# Altium schematic sheet Analog_power.SchDoc(Analog_power)
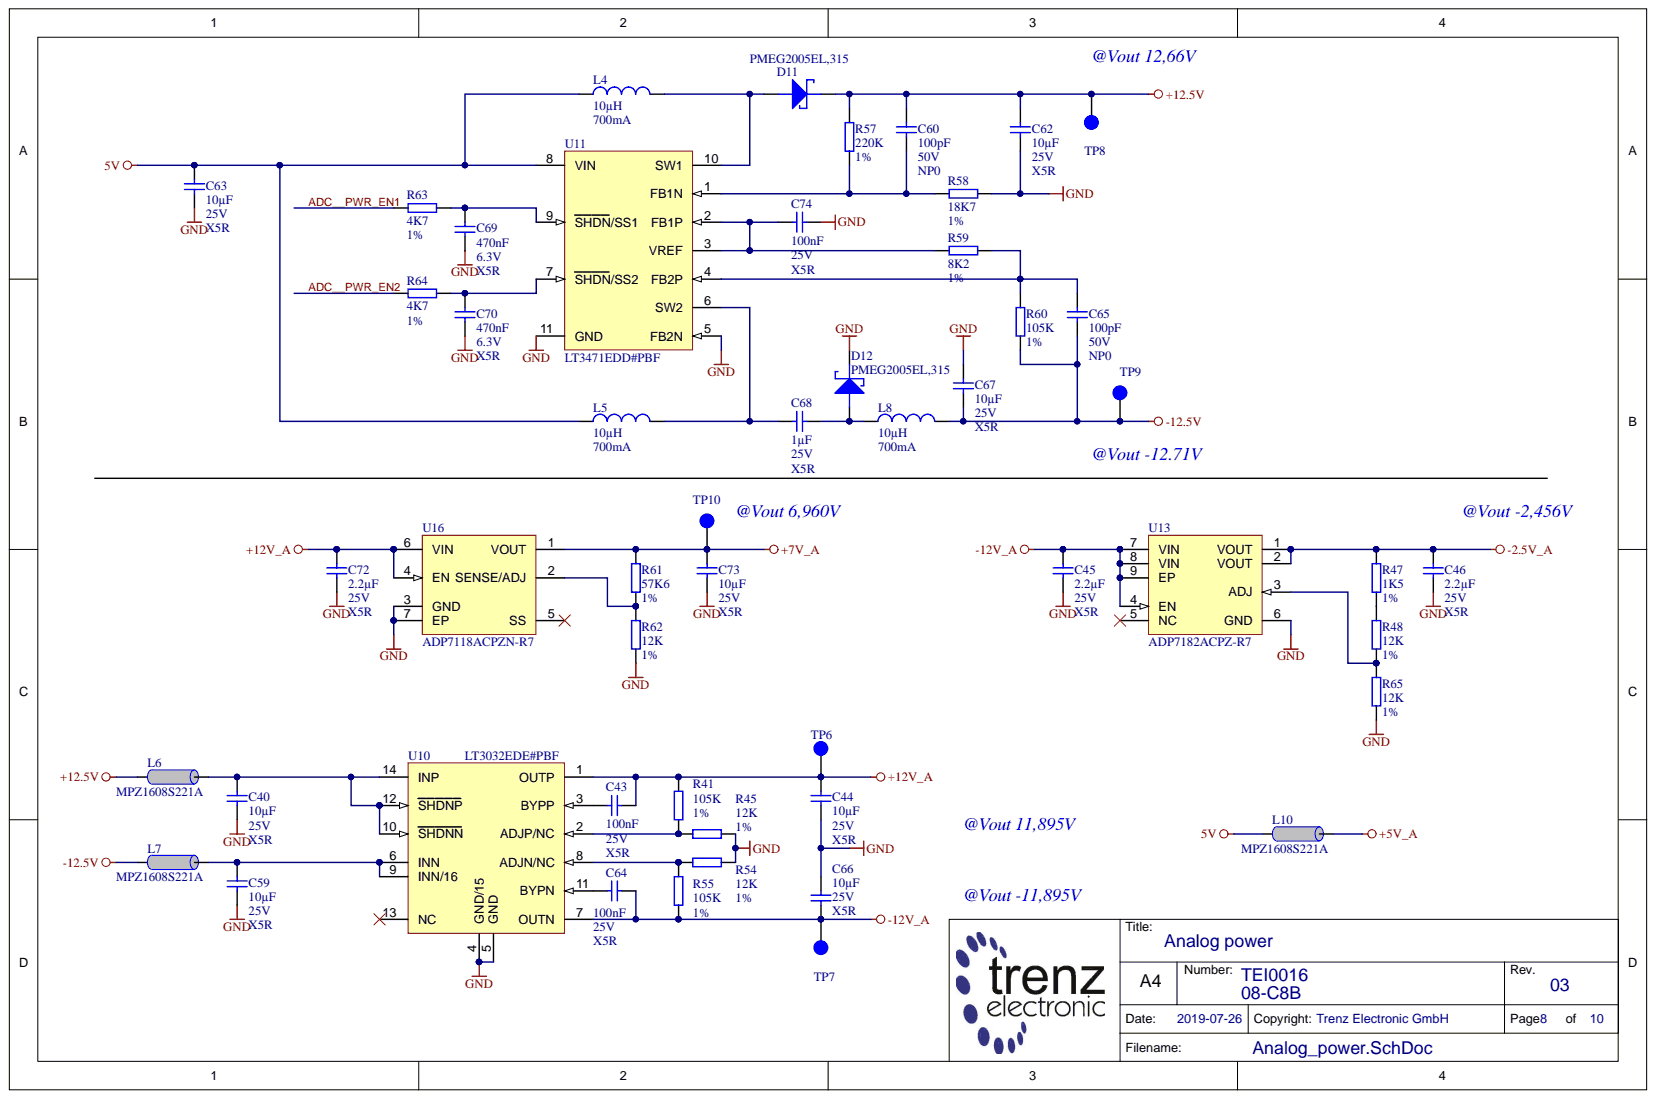
[diagram p0: Analog_power.SchDoc]

## Parts
C40  10µF  Technology: X5R  Voltage: 25V  [CAPC2012X135N]
C43  100nF  [CAPC1005X55N]
C44  10µF  Technology: X5R  Voltage: 25V  [CAPC2012X135N]
C45  2.2µF  Technology: X5R  Voltage: 25V  [CAPC1608X90N]
C46  2.2µF  Technology: X5R  Voltage: 25V  [CAPC1608X90N]
C59  10µF  Technology: X5R  Voltage: 25V  [CAPC2012X135N]
C60  100pF  Voltage: 50V  [CAPC1005X55N]
C62  10µF  Technology: X5R  Voltage: 25V  [CAPC2012X135N]
C63  10µF  Technology: X5R  Voltage: 25V  [CAPC2012X135N]
C64  100nF  Technology: X5R  [CAPC1005X55N]
C65  100pF  Voltage: 50V  [CAPC1005X55N]
C66  10µF  Technology: X5R  Voltage: 25V  [CAPC2012X135N]
C67  10µF  Technology: X5R  Voltage: 25V  [CAPC2012X135N]
C68  1µF  Voltage: 25V  [CAPC1608X90N]
C69  470nF  Technology: X5R  Voltage: 6.3V  [CAPC0603X33N]
C70  470nF  Technology: X5R  Voltage: 6.3V  [CAPC0603X33N]
C72  2.2µF  Technology: X5R  Voltage: 25V  [CAPC1608X90N]
C73  10µF  Technology: X5R  Voltage: 25V  [CAPC2012X135N]
C74  100nF  Voltage: 25V  [CAPC1005X55N]
D11  PMEG2005EL,315  [DIODFN98X58X50-2N]
D12  PMEG2005EL,315  [DIODFN98X58X50-2N]
L4  10µH  Voltage: 700mA  [INDC2520X120N]
L5  10µH  Voltage: 700mA  [INDC2520X120N]
L6  MPZ1608S221A  [INDC1608X95N]
L7  MPZ1608S221A  [INDC1608X95N]
L8  10µH  Voltage: 700mA  [INDC2520X120N]
L10  MPZ1608S221A  [INDC1608X95N]
R41  105K  Tolerance: 1%  [RESC1005X40N]
R45  12K  Tolerance: 1%  [RESC1005X40N]
R47  1K5  Tolerance: 1%  [RESC1005X40N]
R48  12K  Tolerance: 1%  [RESC1005X40N]
R54  12K  Tolerance: 1%  [RESC1005X40N]
R55  105K  Tolerance: 1%  [RESC1005X40N]
R57  220K  Tolerance: 1%  [RESC1005X40N]
R58  18K7  [RESC1005X40N]
R59  8K2  Tolerance: 1%  [RESC1005X40N]
R60  105K  Tolerance: 1%  [RESC1005X40N]
R61  57K6  Tolerance: 1%  [RESC1005X40N]
R62  12K  Tolerance: 1%  [RESC1005X40N]
R63  4K7  Tolerance: 1%  [RESC0603X26N]
R64  4K7  Tolerance: 1%  [RESC0603X26N]
R65  12K  Tolerance: 1%  [RESC1005X40N]
TP6  Testpoint 0.8mm  [TP_0.8mm]  (value not drawn)
TP7  Testpoint 0.8mm  [TP_0.8mm]  (value not drawn)
TP8  Testpoint 0.8mm  [TP_0.8mm]  (value not drawn)
TP9  Testpoint 0.8mm  [TP_0.8mm]  (value not drawn)
TP10  Testpoint 0.8mm  [TP_0.8mm]  (value not drawn)
U10  LT3032EDE#PBF  [SON50P400X300X80-14N-LT]
U11  LT3471EDD#PBF  [SON50P300X300X80-11T164]
U13  ADP7182ACPZ-R7  [SON50P300X300X80-9N]
U16  ADP7118ACPZN-R7  [SON65P200X200X60-7T10X16]

## Nets
5V: C63.1 L4.1 L5.1 L10.1 U11.8(VIN)
+5V_A: L10.2
+7V_A: C73.1 R61.2 TP10.1 U16.1(VOUT)
+12.5V: C60.1 C62.1 D11.1 L6.1 R57.1 TP8.1
+12V_A: C43.2 C44.1 C72.1 R41.1 TP6.1 U10.1(OUTP) U16.4(EN) U16.6(VIN)
-2.5V_A: C46.1 R47.2 U13.1(VOUT) U13.2(VOUT)
-12.5V: C65.2 C67.1 L7.1 L8.2 R60.1 TP9.1
-12V_A: C45.1 C64.2 C66.2 R55.2 TP7.1 U10.7(OUTN) U13.4(EN) U13.7(VIN) U13.8(VIN) U13.9(EP)
GND: C40.2 C44.2 C45.2 C46.2 C59.2 C62.2 C63.2 C66.1 C67.2 C69.2 C70.2 C72.2 C73.2 C74.2 D12.1 R45.2 R54.2 R58.2 R62.1 R65.1 U10.4(GND/15) U10.5(GND) U11.5(FB2N) U11.11(GND) U13.6(GND) U16.3(GND) U16.7(EP)
ADC__PWR_EN1: R63.1
ADC__PWR_EN2: R64.1
NetC40_1: C40.1 L6.2 U10.10(SHDNN) U10.12(SHDNP) U10.14(INP)
NetC43_1: C43.1 U10.3(BYPP)
NetC59_1: C59.1 L7.2 U10.6(INN) U10.9(INN/16)
NetC60_2: C60.2 R57.2 R58.1 U11.1(FB1N)
NetC64_1: C64.1 U10.11(BYPN)
NetC65_1: C65.1 R59.2 R60.2 U11.4(FB2P)
NetC68_1: C68.1 L5.2 U11.6(SW2)
NetC68_2: C68.2 D12.2 L8.1
NetC69_1: C69.1 R63.2 U11.9(SHDN/SS1)
NetC70_1: C70.1 R64.2 U11.7(SHDN/SS2)
NetC74_1: C74.1 R59.1 U11.2(FB1P) U11.3(VREF)
NetD11_2: D11.2 L4.2 U11.10(SW1)
NetR41_2: R41.2 R45.1 U10.2(ADJP/NC)
NetR47_1: R47.1 R48.2
NetR48_1: R48.1 R65.2 U13.3(ADJ)
NetR54_1: R54.1 R55.1 U10.8(ADJN/NC)
NetR61_1: R61.1 R62.2 U16.2(SENSE/ADJ)

Not connected: U10 13(NC); U13 5(NC); U16 5(SS)

## Library data of the parts
C40 C44 C59 C62 C63 C66 C67 C73 = 25567: KON 10µF 25V X5R 10% SMD BF 2012 (0805); Database Table Name: C_2012_0805; Mixed: SMT; Temperature-Range: -55/+85; Value: 10u; AN: 25567; Case: 2012 (0805); Component Kind: Standard; Library Name: TE.DbLib; Tolerance: 10%
C43 C64 C74 = 22472: KON 100nF 25V X5R ±10% SMD BF 1005 (0402); Database Table Name: C_1005_0402; Mixed: SMT; Preferred: Yes; Temperature-Range: -55/+85; Value: 100n; AN: 22472; Case: 1005 (0402); Component Kind: Standard; Library Name: TE.DbLib
  C43: Technology: X5R; Voltage: 25V
  C64: Voltage: 25V
  C74: Technology: X5R
C45 C46 C72 = 27910: KON 2.2uF 25V X5R ±10% SMD BF 1608 (0603); Database Table Name: C_1608_0603; Mixed: SMT; Temperature-Range: -55/+85; Value: 2.2u; AN: 27910; Case: 1608 (0603); Component Kind: Standard; Library Name: TE.DbLib; Tolerance: ±10%
C60 C65 = 23123: KON 100pF 50V NP0 5% SMD BF 1005 (0402); Database Table Name: C_1005_0402; Mixed: SMT; Preferred: Yes; Value: 100p; AN: 23123; Case: 1005 (0402); Component Kind: Standard; Library Name: TE.DbLib; Technology: NP0
C68 = 26837: KON 1µF 25V X5R ±10% SMD BF 1608 (0603); Database Table Name: C_1608_0603; Mixed: SMT; Preferred: Yes; Temperature-Range: -55/+85; Value: 1u; AN: 26837; Case: 1608 (0603); Component Kind: Standard; Library Name: TE.DbLib; Technology: X5R; Tolerance: 10%
C69 C70 = 24921: KON 470nF 6.3V X5R 20%  SMD BF 0603 (0201); Database Table Name: C_0603_0201; Mixed: SMT; Preferred: Yes; Temperature-Range: -55/+85; Value: 470n; AN: 24921; Case: 0603 (0201); Component Kind: Standard; Library Name: TE.DbLib; Tolerance: 20%
D11 D12 = 29676: Database Table Name: DIODE; Mixed: SMT; Temperature-Range: -65/+150; Value: 0.5A; Voltage: 20V; AN: 29676; Case: SOD882 / DFN1006-2; Component Kind: Standard; Description: PMEG2005EL,315; H-ART: PMEG2005EL,315; Library Name: TE.DbLib; Storage-Temperature: -65/+150(Abs); Technology: Schottky; HelpURL: b:\AD\adlib\datasheet\PMEG2005EL\
L4 L5 L8 = 29717: IND SMD 10µH 20% 700mA 280mOhms 1008 (2520); Database Table Name: L_SMD; H-ART: MLP2520S100ST0S1; Mixed: SMT; Temperature-Range: -40/+125; Value: 10u; AN: 29717; Case: 1008 (2520); Component Kind: Standard; Library Name: TE.DbLib; Technology: 280mOhm; Tolerance: 20%; HelpURL: b:\AD\adlib\datasheet\TDK_MLP2520\
L6 L7 L10 = 22718: Ferritdurchführung MPZ1608S221A; Database Table Name: L_1608_0603; Mixed: SMT; Preferred: Yes; Value: 220 OHm @ 100MHz; AN: 22718; Case: 1608 (0603); Component Kind: Standard; H-ART: MPZ1608S221A; Library Name: TE.DbLib; Trenz-Stock: Yes; HelpURL: B:\AD\adlib\datasheet\MPZ1608
R41 R55 R60 = 26467: WID SMD 105K 1% 0,063W; Database Table Name: R_1005_0402; Mixed: SMT; Preferred: Yes; AN: 26467; Case: 1005 (0402); Component Kind: Standard; Library Name: TE.DbLib; Technology: TK100; Value: 105K
R45 R48 R54 R62 R65 = 22598: WID SMD 12K 1% 0,063W  BF 1005 (0402); Database Table Name: R_1005_0402; Mixed: SMT; Preferred: Yes; AN: 22598; Case: 1005 (0402); Component Kind: Standard; Library Name: TE.DbLib; Technology: TK100; Value: 12K
R47 = 22602: WID SMD 1K5 1% 0,063W BF 1005 (0402); Database Table Name: R_1005_0402; Mixed: SMT; Preferred: Yes; Value: 1.5K; AN: 22602; Case: 1005 (0402); Component Kind: Standard; Library Name: TE.DbLib; Technology: TK100
R57 = 23918: WID SMD 220K 1% 0,063W; Database Table Name: R_1005_0402; Mixed: SMT; AN: 23918; Case: 1005 (0402); Component Kind: Standard; Library Name: TE.DbLib; Technology: TK100; Value: 220k
R58 = 25487: WID SMD 18K7 1% 0,063W; Database Table Name: R_1005_0402; Mixed: SMT; Preferred: Yes; Value: 18.7K; AN: 25487; Case: 1005 (0402); Component Kind: Standard; Library Name: TE.DbLib; Technology: TK100; Tolerance: 1%
R59 = 27491: WID SMD 8K2 1% 0,063W BF 1005 (0402); Database Table Name: R_1005_0402; Mixed: SMT; Value: 8.2K; AN: 27491; Case: 1005 (0402); Component Kind: Standard; Library Name: TE.DbLib; Technology: TK100
R61 = 24958: WID SMD 57K6 1% 0,063W  BF 1005 (0402); Database Table Name: R_1005_0402; Mixed: SMT; Preferred: Yes; Value: 57.6K; AN: 24958; Case: 1005 (0402); Component Kind: Standard; Library Name: TE.DbLib; Technology: TK100
R63 R64 = 25242: WID SMD 4K7 1% 0,05W  BF 0603 (0201); Database Table Name: R_0603_0201; H-ART: RC0201FR-074K7L; Mixed: SMT; Preferred: Yes; Value: 4.7K; AN: 25242; Case: 0603 (0201); Component Kind: Standard; Library Name: TE.DbLib; Technology: TK100; HelpURL: B:\AD\adlib\datasheet\R0201
TP6-TP10 = 26289: Database Table Name: PCB; Mixed: MECH; AN: 26289; Component Kind: Standard; Description: Testpoint 0.8mm; Library Name: TE.DbLib
U10 = 24666: Database Table Name: LREG; Mixed: SMT; Trenz-Stock: Yes; AN: 24666; Case: DFN14LT; Component Kind: Standard; Description: LT3032EDE#PBF; H-ART: LT3032EDE#PBF; Library Name: TE.DbLib; HelpURL: B:\AD\adlib\datasheet\LT3032
U11 = 29486: Database Table Name: DCDC; Mixed: SMT; Temperature-Range: -40/+85; Trenz-Stock: Yes; AN: 29486; Case: WFDFN10; Component Kind: Standard; Description: LT3471EDD#PBF; H-ART: LT3471EDD#PBF; Library Name: TE.DbLib; Storage-Temperature: -65/+125(Abs); HelpURL: b:\AD\adlib\datasheet\LT3471\
U13 = 28212: Database Table Name: LREG; Mixed: SMT; AN: 28212; Case: DFN8; Component Kind: Standard; Description: ADP7182ACPZ-R7; H-ART: ADP7182ACPZ-R7; Library Name: TE.DbLib; HelpURL: b:\AD\adlib\datasheet\ADP7182\
U16 = 27881: Database Table Name: LREG; Mixed: SMT; AN: 27881; Case: DFN8; Component Kind: Standard; Description: ADP7118ACPZN-R7; H-ART: ADP7118ACPZN-R7; Library Name: TE.DbLib; HelpURL: b:\AD\adlib\datasheet\ADP7118\
